# Altium schematic sheet StrainGauge.SchDoc(StrainGauge)
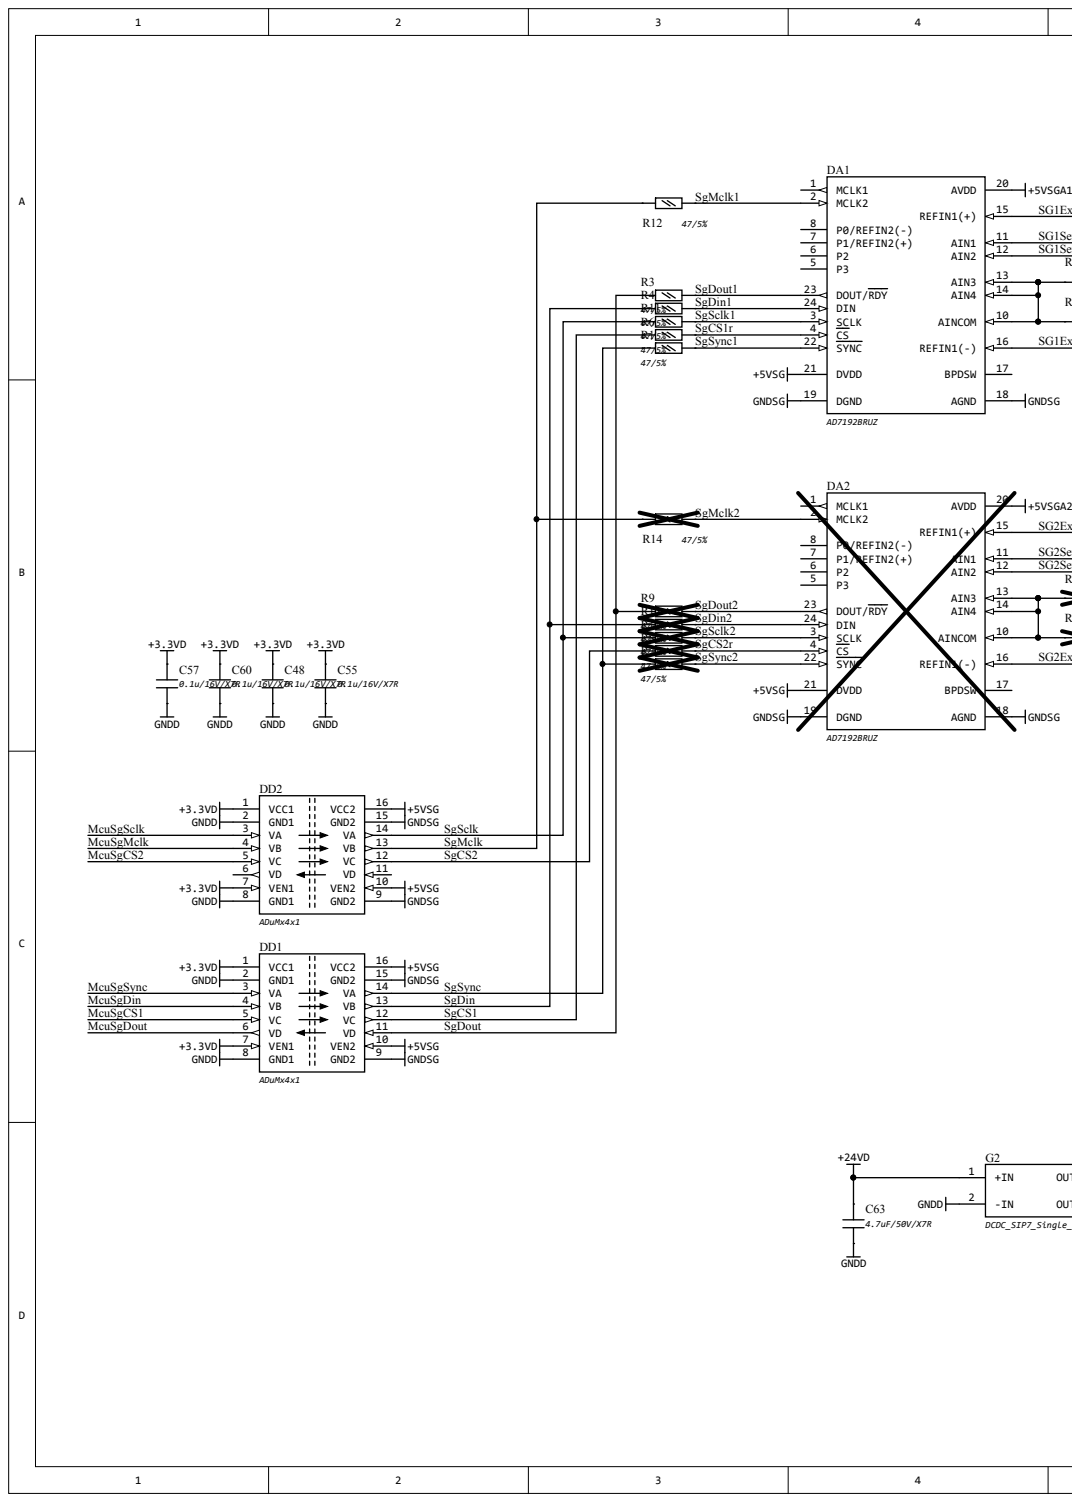
[diagram p0: StrainGauge.SchDoc, left]
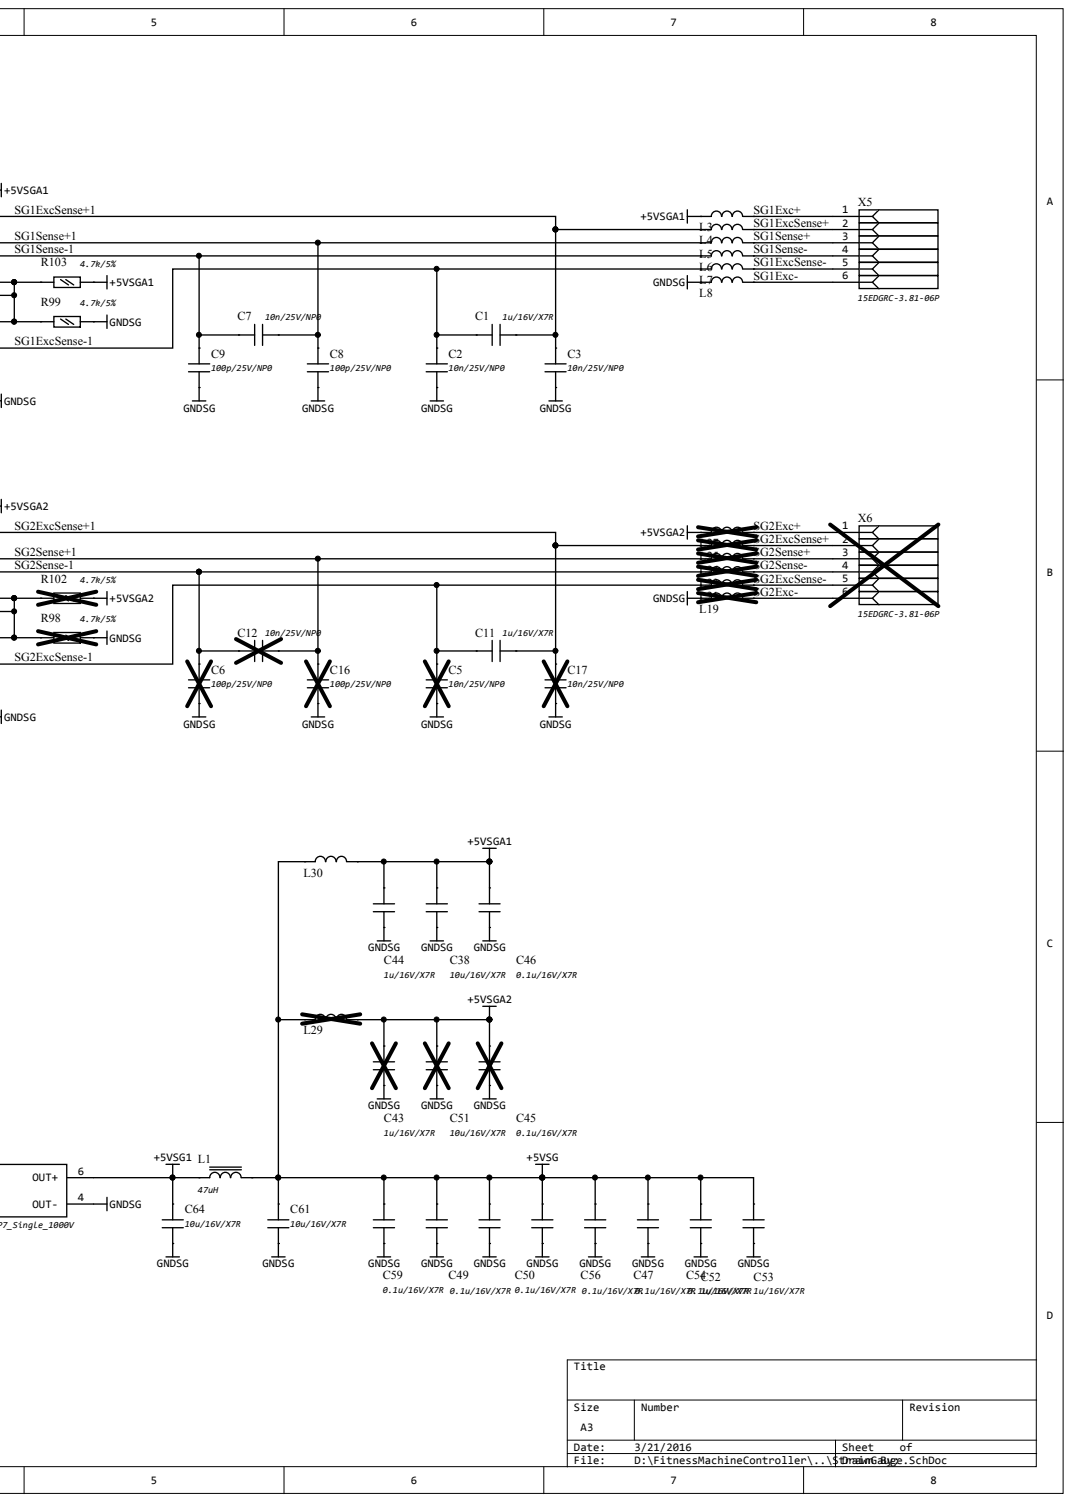
[diagram p1: StrainGauge.SchDoc, right]

## Parts
C1  1u/16V/X7R
C2  10n/25V/NP0
C3  10n/25V/NP0
C5  10n/25V/NP0
C6  100p/25V/NP0
C7  10n/25V/NP0
C8  100p/25V/NP0
C9  100p/25V/NP0
C11  1u/16V/X7R
C12  10n/25V/NP0
C16  100p/25V/NP0
C17  10n/25V/NP0
C38  10u/16V/X7R
C43  1u/16V/X7R
C44  1u/16V/X7R
C45  0.1u/16V/X7R
C46  0.1u/16V/X7R
C47  0.1u/16V/X7R
C48  0.1u/16V/X7R
C49  0.1u/16V/X7R
C50  0.1u/16V/X7R
C51  10u/16V/X7R
C52  1u/16V/X7R
C53  1u/16V/X7R
C54  0.1u/16V/X7R
C55  0.1u/16V/X7R
C56  0.1u/16V/X7R
C57  0.1u/16V/X7R
C59  0.1u/16V/X7R
C60  0.1u/16V/X7R
C61  10u/16V/X7R
C63  4.7uF/50V/X7R
C64  10u/16V/X7R
DA1  AD7192BRUZ
DA2  AD7192BRUZ
DD1  ADuMx4x1
DD2  ADuMx4x1
G2  DCDC_SIP7_Single_1000V
L1  47uH
L3  FerriteFilterBLM18  (value not drawn)
L4  FerriteFilterBLM18  (value not drawn)
L5  FerriteFilterBLM18  (value not drawn)
L6  FerriteFilterBLM18  (value not drawn)
L7  FerriteFilterBLM18  (value not drawn)
L8  FerriteFilterBLM18  (value not drawn)
L19  FerriteFilterBLM18  (value not drawn)
L21  FerriteFilterBLM18  (value not drawn)
L23  FerriteFilterBLM18  (value not drawn)
L24  FerriteFilterBLM18  (value not drawn)
L25  FerriteFilterBLM18  (value not drawn)
L27  FerriteFilterBLM18  (value not drawn)
L29  FerriteFilterBLM18  (value not drawn)
L30  FerriteFilterBLM18  (value not drawn)
R1  47/5%
R3  47/5%
R4  47/5%
R6  47/5%
R7  47/5%
R8  47/5%
R9  47/5%
R10  47/5%
R11  47/5%
R12  47/5%
R13  47/5%
R14  47/5%
R98  4.7k/5%
R99  4.7k/5%
R102  4.7k/5%
R103  4.7k/5%
X5  15EDGRC-3.81-06P
X6  15EDGRC-3.81-06P

## Nets
+3.3VD: C48.2 C55.2 C57.2 C60.2 DD1.1(VCC1) DD1.7(VEN1) DD2.1(VCC1) DD2.7(VEN1)
+5VSG: C47.2 C49.2 C50.2 C52.2 C53.2 C54.2 C56.2 C59.2 C61.2 DA1.21(DVDD) DA2.21(DVDD) DD1.10(VEN2) DD1.16(VCC2) DD2.10(VEN2) DD2.16(VCC2) L1.2 L29.1 L30.1
+5VSG1: C64.2 G2.6(OUT+) L1.1
+5VSGA1: C38.2 C44.2 C46.2 DA1.20(AVDD) L3.1 L30.2 R103.2
+5VSGA2: C43.2 C45.2 C51.2 DA2.20(AVDD) L27.1 L29.2 R102.2
+24VD: C63.2 G2.1(+IN)
GNDD: C48.1 C55.1 C57.1 C60.1 C63.1 DD1.2(GND1) DD1.8(GND1) DD2.2(GND1) DD2.8(GND1) G2.2(-IN)
GNDSG: C2.2 C3.2 C5.2 C6.1 C8.1 C9.1 C16.1 C17.2 C38.1 C43.1 C44.1 C45.1 C46.1 C47.1 C49.1 C50.1 C51.1 C52.1 C53.1 C54.1 C56.1 C59.1 C61.1 C64.1 DA1.18(AGND) DA1.19(DGND) DA2.18(AGND) DA2.19(DGND) DD1.9(GND2) DD1.15(GND2) DD2.9(GND2) DD2.15(GND2) G2.4(OUT-) L8.1 L19.1 R98.2 R99.2
MCUSGCS1: DD1.5(VC)
MCUSGCS2: DD2.5(VC)
MCUSGDIN: DD1.4(VB)
MCUSGDOUT: DD1.6(VD)
MCUSGMCLK: DD2.4(VB)
MCUSGSCLK: DD2.3(VA)
MCUSGSYNC: DD1.3(VA)
SG1EXC+: L3.2 X5.1
SG1EXC-: L8.2 X5.6
SG1EXCSENSE+: L4.2 X5.2
SG1EXCSENSE+1: C1.1 C3.1 DA1.15(REFIN1(+)) L4.1
SG1EXCSENSE-: L7.2 X5.5
SG1EXCSENSE-1: C1.2 C2.1 DA1.16(REFIN1(-)) L7.1
SG1SENSE+: L5.2 X5.3
SG1SENSE+1: C7.1 C8.2 DA1.11(AIN1) L5.1
SG1SENSE-: L6.2 X5.4
SG1SENSE-1: C7.2 C9.2 DA1.12(AIN2) L6.1
SG2EXC+: L27.2 X6.1
SG2EXC-: L19.2 X6.6
SG2EXCSENSE+: L25.2 X6.2
SG2EXCSENSE+1: C11.1 C17.1 DA2.15(REFIN1(+)) L25.1
SG2EXCSENSE-: L21.2 X6.5
SG2EXCSENSE-1: C5.1 C11.2 DA2.16(REFIN1(-)) L21.1
SG2SENSE+: L24.2 X6.3
SG2SENSE+1: C12.1 C16.2 DA2.11(AIN1) L24.1
SG2SENSE-: L23.2 X6.4
SG2SENSE-1: C6.2 C12.2 DA2.12(AIN2) L23.1
SGCS1: DD1.12(VC) R6.2
SGCS1R: DA1.4(CS) R6.1
SGCS2: DD2.12(VC) R8.2
SGCS2R: DA2.4(CS) R8.1
SGDIN: DD1.13(VB) R4.2 R13.2
SGDIN1: DA1.24(DIN) R4.1
SGDIN2: DA2.24(DIN) R13.1
SGDOUT: DD1.11(VD) R3.2 R9.2
SGDOUT1: DA1.23(DOUT/RDY) R3.1
SGDOUT2: DA2.23(DOUT/RDY) R9.1
SGMCLK: DD2.13(VB) R12.2 R14.2
SGMCLK1: DA1.2(MCLK2) R12.1
SGMCLK2: DA2.2(MCLK2) R14.1
SGSCLK: DD2.14(VA) R10.2 R11.2
SGSCLK1: DA1.3(SCLK) R11.1
SGSCLK2: DA2.3(SCLK) R10.1
SGSYNC: DD1.14(VA) R1.2 R7.2
SGSYNC1: DA1.22(SYNC) R1.1
SGSYNC2: DA2.22(SYNC) R7.1
NetDA1_10: DA1.10(AINCOM) DA1.13(AIN3) DA1.14(AIN4) R99.1 R103.1
NetDA2_10: DA2.10(AINCOM) DA2.13(AIN3) DA2.14(AIN4) R98.1 R102.1

Not connected: DA1 1(MCLK1) 5(P3) 6(P2) 7(P1/REFIN2(+)) 8(P0/REFIN2(-)) 17(BPDSW); DA2 1(MCLK1) 5(P3) 6(P2) 7(P1/REFIN2(+)) 8(P0/REFIN2(-)) 17(BPDSW); DD2 6(VD) 11(VD)

## Library data of the parts
C1 C11 C43 C44 C52 C53 = (no symbol name): ComponentDescriptionRUS: Конденсатор SMD 0805; Analog: нет; NumberSolderPointsSMD: 0; NumberSolderPointsTHT: 0
C2 C3 C5-C9 C12 C16 C17 C45-C50 C54-C57 C59 C60 = (no symbol name): ComponentDescriptionRUS: Конденсатор SMD 0603; Analog: нет; NumberSolderPointsSMD: 0; NumberSolderPointsTHT: 0
C38 C51 C61 C64 = (no symbol name): ComponentDescriptionRUS: Конденсатор SMD 1206; Analog: нет; NumberSolderPointsSMD: 0; NumberSolderPointsTHT: 0
C63 = (no symbol name): ComponentDescriptionRUS: Конденсатор SMD 1210; Analog: нет; NumberSolderPointsSMD: 0; NumberSolderPointsTHT: 0
DD1 DD2 = (no symbol name): Analog: нет; NumberSolderPointsSMD: 0; NumberSolderPointsTHT: 0
G2 = (no symbol name): ComponentDescriptionRUS: Модуль питания; Analog: нет; NumberSolderPointsSMD: 0; NumberSolderPointsTHT: 0
L1 = (no symbol name): ComponentDescriptionRUS: Индуктивность SMD 2525; Analog: нет; NumberSolderPointsSMD: 0; NumberSolderPointsTHT: 0
L3-L8 L19 L21 L23-L25 L27 L29 L30 = (no symbol name): ComponentDescriptionRUS: Фильтр SMD 0603; Analog: нет; NumberSolderPointsSMD: 0; NumberSolderPointsTHT: 0
R1 R3 R4 R6-R14 R98 R99 R102 R103 = (no symbol name): ComponentDescriptionRUS: Резистор SMD 0603; Analog: нет; NumberSolderPointsSMD: 0; NumberSolderPointsTHT: 0
X5 X6 = (no symbol name): Аналог: ECH381R-06P; NumberSolderPointsSMD: 0; Комплект: EC381V-06P, 15EDGK-3.81-06; NumberSolderPointsTHT: 0
